# Altium schematic sheet MCU.SchDoc
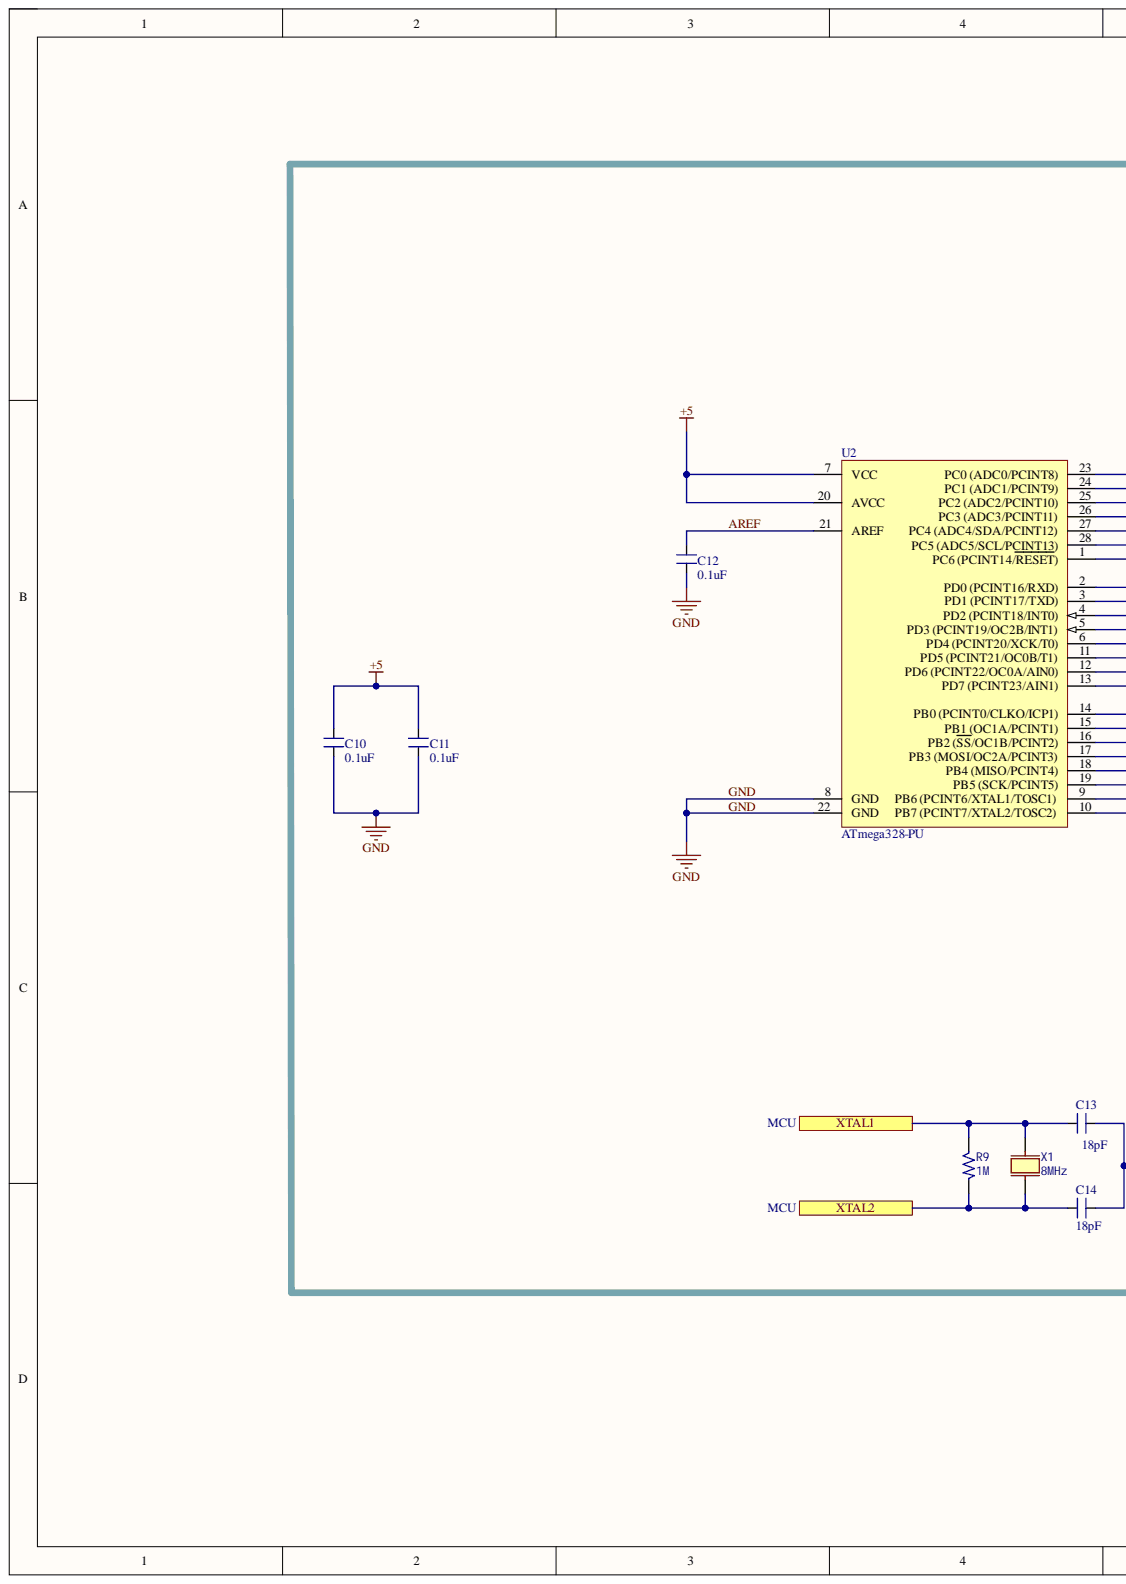
[diagram p0: MCU.SchDoc, left]
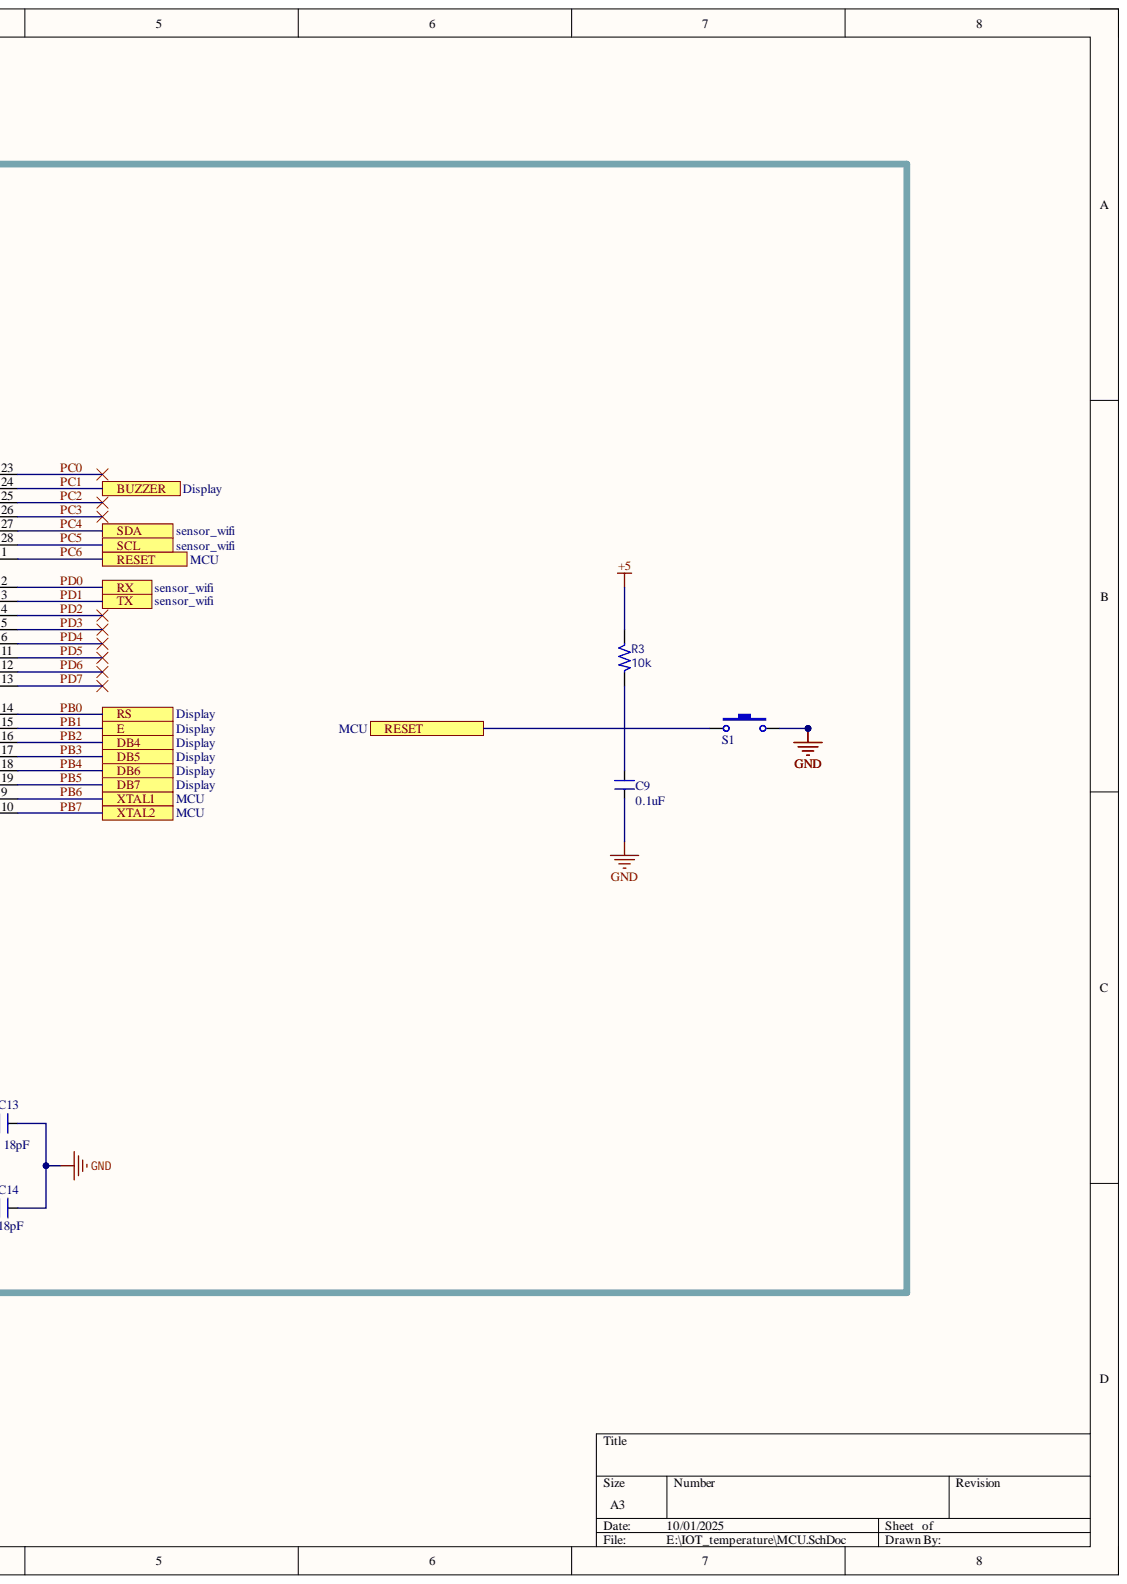
[diagram p1: MCU.SchDoc, right]

## Parts
C9  0.1uF  [CN2]
C10  0.1uF  [CN2]
C11  0.1uF  [CN2]
C12  0.1uF  [CN2]
C13  18pF  [CN3]
C14  18pF  [CN3]
R3  10k  [RESISTOR_0603]
R9  1M  [RESISTOR_0603]
S1  SW  [BUTTON  ROUND]  (value not drawn)
U2  ATmega328-PU  [DIP28]
X1  8MHz  [CM8V-T1A-32.768KHZ]

## Ports
BUZZER, DB4, DB5, DB6, DB7, E, RESET, RS, RX, SCL, SDA, TX, XTAL1, XTAL2

## Nets
+5: C10.2 C11.2 R3.1 U2.7(VCC) U2.20(AVCC)
AREF: C12.2 U2.21(AREF)
GND: C9.1 C10.1 C11.1 C12.1 C13.2 C14.2 S1.2 U2.8(GND) U2.22(GND)
PB0: U2.14(PB0_(PCINT0/CLKO/ICP1)) ; port RS
PB1: U2.15(PB1_(OC1A/PCINT1)) ; port E
PB2: U2.16(PB2_(SS/OC1B/PCINT2)) ; port DB4
PB3: U2.17(PB3_(MOSI/OC2A/PCINT3)) ; port DB5
PB4: U2.18(PB4_(MISO/PCINT4)) ; port DB6
PB5: U2.19(PB5_(SCK/PCINT5)) ; port DB7
PB6: C13.1 R9.1 U2.9 X1.2 ; port XTAL1
PB7: C14.1 R9.2 U2.10 X1.1 ; port XTAL2
PC0: U2.23(PC0_(ADC0/PCINT8))
PC1: U2.24(PC1_(ADC1/PCINT9)) ; port BUZZER
PC2: U2.25
PC3: U2.26(PC3_(ADC3/PCINT11))
PC4: U2.27 ; port SDA
PC5: U2.28(PC5_(ADC5/SCL/PCINT13)) ; port SCL
PC6: S1.1 U2.1(PC6_(PCINT14/RESET)) ; port RESET
PD0: U2.2(PD0_(PCINT16/RXD)) ; port RX
PD1: U2.3(PD1_(PCINT17/TXD)) ; port TX
PD2: U2.4(PD2_(PCINT18/INT0))
PD3: U2.5(PD3_(PCINT19/OC2B/INT1))
PD4: U2.6(PD4_(PCINT20/XCK/T0))
PD5: U2.11(PD5_(PCINT21/OC0B/T1))
PD6: U2.12(PD6_(PCINT22/OC0A/AIN0))
PD7: U2.13(PD7_(PCINT23/AIN1))
NetC9_2: C9.2 R3.2

## Library data of the parts
C9-C12 = CN2: Cap np 104 => 105pF; Component Kind: Standard; Value: 0.1uF; Library Name: Compiled_Devzone.IntLib
C13 C14 = CN3: Cap np W0.3; Value: 0,047uF; Component Kind: Standard; Copyright: VanDungEvn; Library Name: Compiled_Devzone.IntLib
R3 R9 = RES-SMD: Component Kind: Standard; Library Name: ID.SchLib; Signal Integrity: Guessed Model
S1 = SW-Button: Switch-Button; Component Kind: Standard; LatestRevisionDate: 17-Jul-2002; LatestRevisionNote: Re-released for DXP Platform.; PackageReference: SPST-2; Published: 24-Mar-1999; Library Name: KhanhBK_Library_4.IntLib; Publisher: Altium Limited
U2 = ATmega328-PU: 8-bit AVR Microcontroller, 32KB Flash, 1KB EEPROM, 2KB SRAM, 28-pin PDIP, Industrial Grade (-40°C to 85°C); Component Kind: Standard; ComponentLink1Description: Manufacturer Link; ComponentLink1URL: http://www.atmel.com/products/avr/default.asp?; ComponentLink2Description: ATmega48A/48PA/88A/88PA/168A/168PA/328/328P Datasheet; ComponentLink2URL: http://www.atmel.com/dyn/resources/prod_documents/8271.pdf; ComponentLink3Description: ATmega48A/48PA/88A/88PA/168A/168PA/328/328P Summary; ComponentLink3URL: http://www.atmel.com/dyn/resources/prod_documents/8271S.pdf; ComponentLink4Description: Atmel.com Home; ComponentLink4URL: http://www.atmel.com; DatasheetVersion: revA, Jan-2010; Library Name: Altium Library.IntLib; PackageDescription: 28-lead (0.300"/7.62mm Wide) Plastic Dual Inline Package (PDIP); PackageReference: 28P3; PackageVersion: revB, Sep-2001; Published: 1-Apr-2010; Supplier 1: Digi-Key; Supplier 2: Mouser; Supplier Part Number 1: ATMEGA328-PU-ND; Supplier Part Number 2: 556-ATMEGA328-PU; Publisher: Altium Limited
X1 = CRYSTAL 2PIN: Part Number: ABS07-120-32.768KHZ-T; Value: 32.768kHz; Component Kind: Standard; Signal Integrity: Guessed Model; Library Name: tutorial-a1.SchLib
